# Altium schematic sheet 04_POWER.SchDoc(04_POWER)
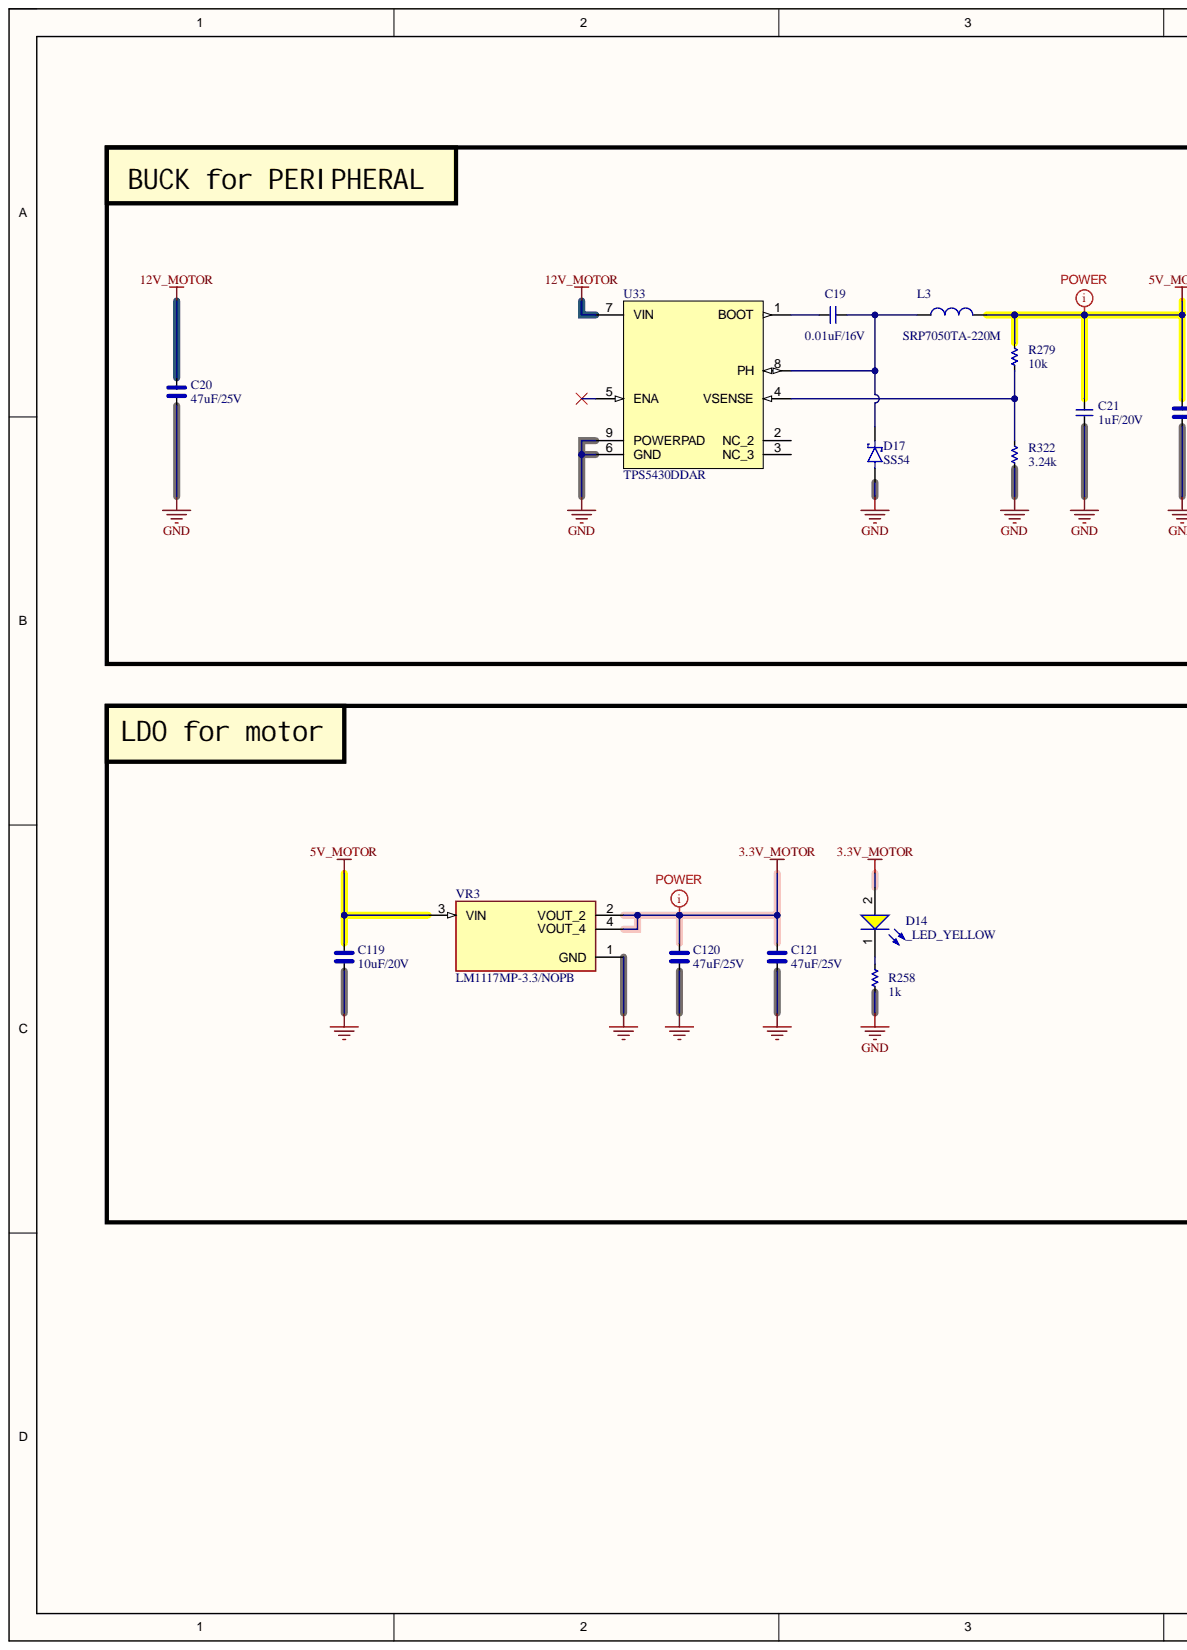
[diagram p0: 04_POWER.SchDoc, left]
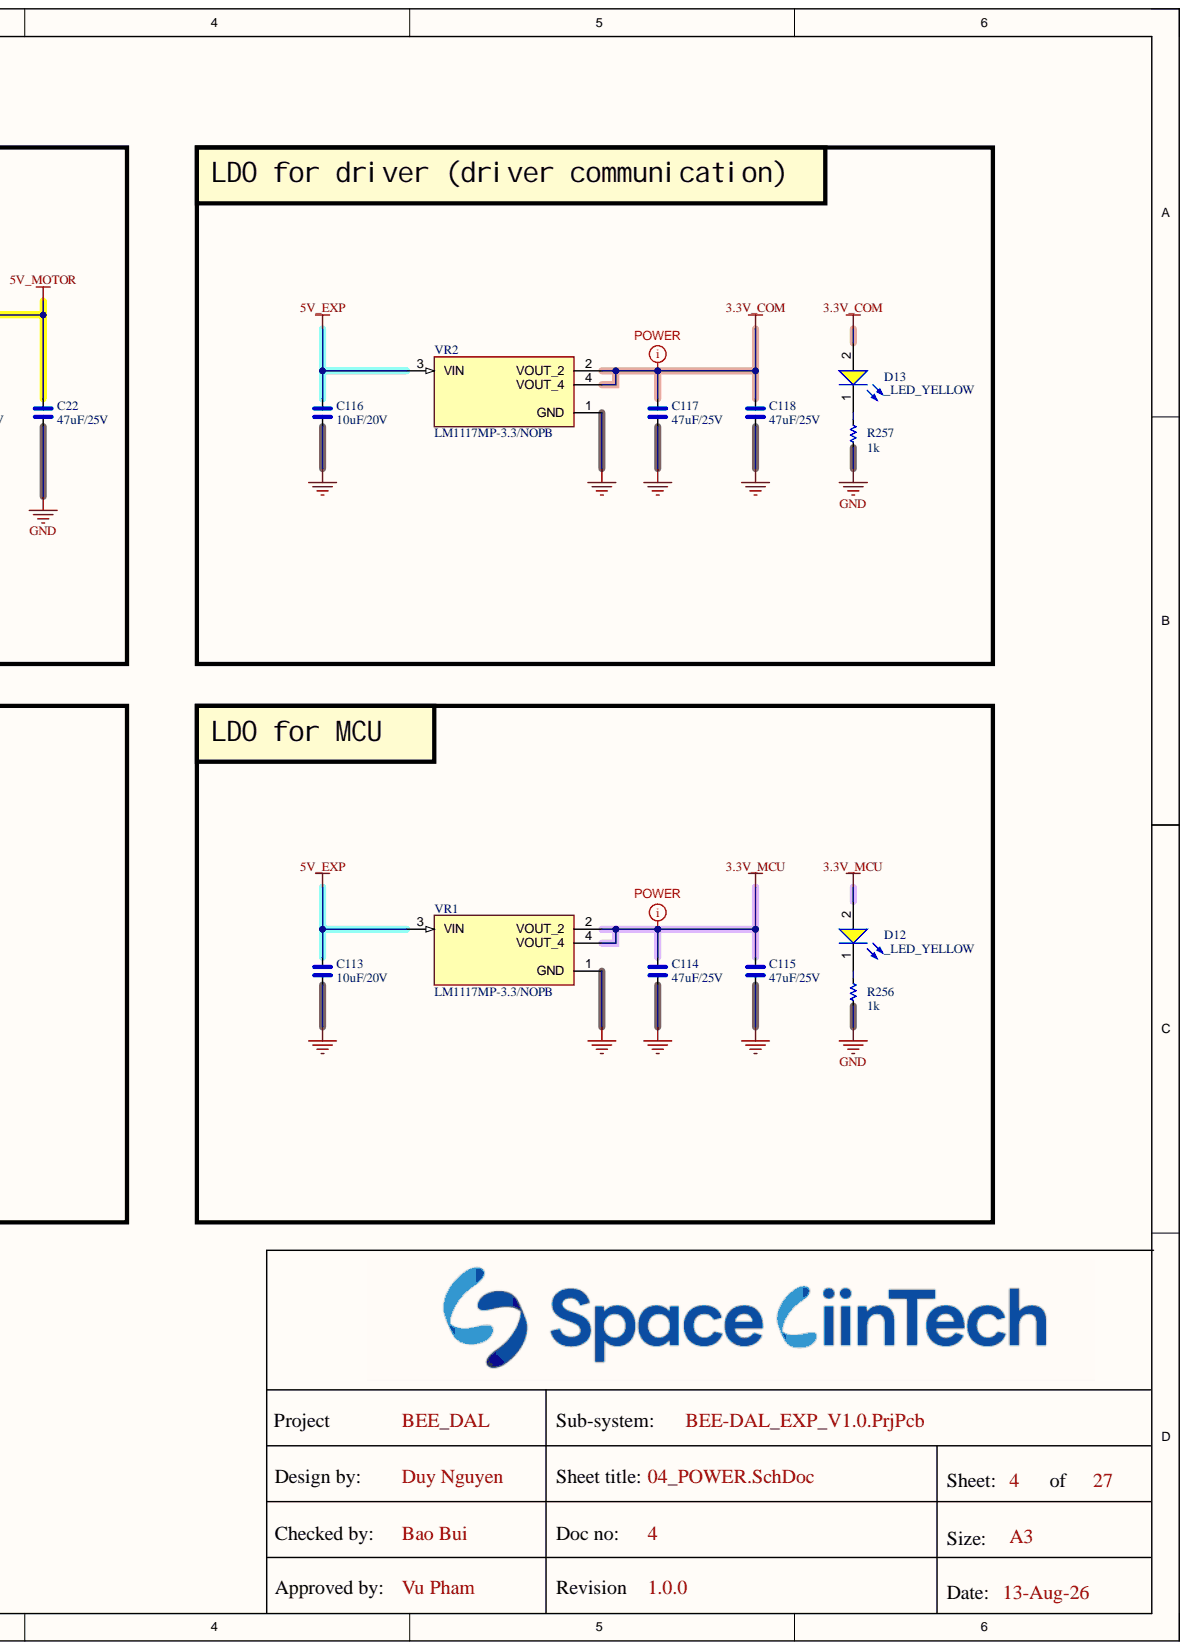
[diagram p1: 04_POWER.SchDoc, right]

## Parts
C19  0.01uF/16V  [CAP_C_1608X80]
C20  47uF/25V  [CAP_C_3225X80]
C21  1uF/20V  [CAP_C_1005X60]
C22  47uF/25V  [CAP_C_3225X80]
C113  10uF/20V  [CAP_C_2012X110]
C114  47uF/25V  [CAP_C_3225X80]
C115  47uF/25V  [CAP_C_3225X80]
C116  10uF/20V  [CAP_C_2012X110]
C117  47uF/25V  [CAP_C_3225X80]
C118  47uF/25V  [CAP_C_3225X80]
C119  10uF/20V  [CAP_C_2012X110]
C120  47uF/25V  [CAP_C_3225X80]
C121  47uF/25V  [CAP_C_3225X80]
D12  _LED_YELLOW  [LED_0603]
D13  _LED_YELLOW  [LED_0603]
D14  _LED_YELLOW  [LED_0603]
D17  SS54  [DO-214AC_SMA]
L3  SRP7050TA-220M  [PSM6050]
R256  1k  [RES_C_1005X40]
R257  1k  [RES_C_1005X40]
R258  1k  [RES_C_1005X40]
R279  10k  [RES_C_1005X40]
R322  3.24k  [RES_C_1005X40]
U33  TPS5430DDAR  [TPS54531DDAR]
VR1  LM1117MP-3.3/NOPB  [VREG_LM1117MP-3.3/NOPB]
VR2  LM1117MP-3.3/NOPB  [VREG_LM1117MP-3.3/NOPB]
VR3  LM1117MP-3.3/NOPB  [VREG_LM1117MP-3.3/NOPB]

## Nets
3.3V_COM: C117.2 C118.2 D13.2 VR2.2(VOUT_2) VR2.4(VOUT_4)
3.3V_MCU: C114.2 C115.2 D12.2 VR1.2(VOUT_2) VR1.4(VOUT_4)
3.3V_MOTOR: C120.2 C121.2 D14.2 VR3.2(VOUT_2) VR3.4(VOUT_4)
5V_EXP: C113.1 C116.1 VR1.3(VIN) VR2.3(VIN)
5V_MOTOR: C21.2 C22.2 C119.1 L3.2 R279.2 VR3.3(VIN)
12V_MOTOR: C20.2 U33.7(VIN)
GND: C20.1 C21.1 C22.1 C113.2 C114.1 C115.1 C116.2 C117.1 C118.1 C119.2 C120.1 C121.1 D17.2 R256.1 R257.1 R258.1 R322.1 U33.6(GND) U33.9(POWERPAD) VR1.1(GND) VR2.1(GND) VR3.1(GND)
NetC19_1: C19.1 D17.1 L3.1 U33.8(PH)
NetC19_2: C19.2 U33.1(BOOT)
NetD12_1: D12.1 R256.2
NetD13_1: D13.1 R257.2
NetD14_1: D14.1 R258.2
NetR279_1: R279.1 R322.2 U33.4(VSENSE)

Not connected: U33 2(NC_2) 3(NC_3) 5(ENA)

## Library data of the parts
C19 C21 = _CAP_C_2: CAP; Component Kind: Standard; Library Name: LABLIB.SchLib
C20 C22 C113-C121 = _CAP_C_High_2: CAP High Value; Component Kind: Standard; Library Name: LABLIB.SchLib
D17 = DIODE SCHOTTKY: Component Kind: Standard; Description: DIODE SCHOTTKY; Library Name: LABLIB.SchLib
L3 = SRP7050TA-220M: FIXED IND 22UH 2.5A 170 MOHM SMD; Component Kind: Standard; Library Name: LABLIB.SchLib
R256-R258 R279 R322 = _RES_B_2: RES; Component Kind: Standard; Library Name: LABLIB.SchLib
U33 = TPS5430DDAR: IC REG BUCK ADJ 3A 8SOPWR; Component Kind: Standard; Library Name: BEE-DAL_EXP_sche.SCHLIB
VR1-VR3 = LM1117MP-3.3/NOPB: 800-mA 15-V linear voltage regulator; MF: Texas Instruments; Check_prices: https://www.snapeda.com/parts/LM1117MP-3.3/NOPB/Texas+Instruments/view-part/?ref=eda; Component Kind: Standard; MP: LM1117MP-3.3/NOPB; Package: SOT-223-4 Texas Instruments; SnapEDA_Link: https://www.snapeda.com/parts/LM1117MP-3.3/NOPB/Texas+Instruments/view-part/?ref=snap; Library Name: LABLIB.SchLib
D12-D14 = _LED_YELLOW: Component Kind: Standard; Library Name: LABLIB.SchLib
